# Altium schematic sheet lv_com_rs232_rs485.SchDoc(lv_com_rs232_rs485)
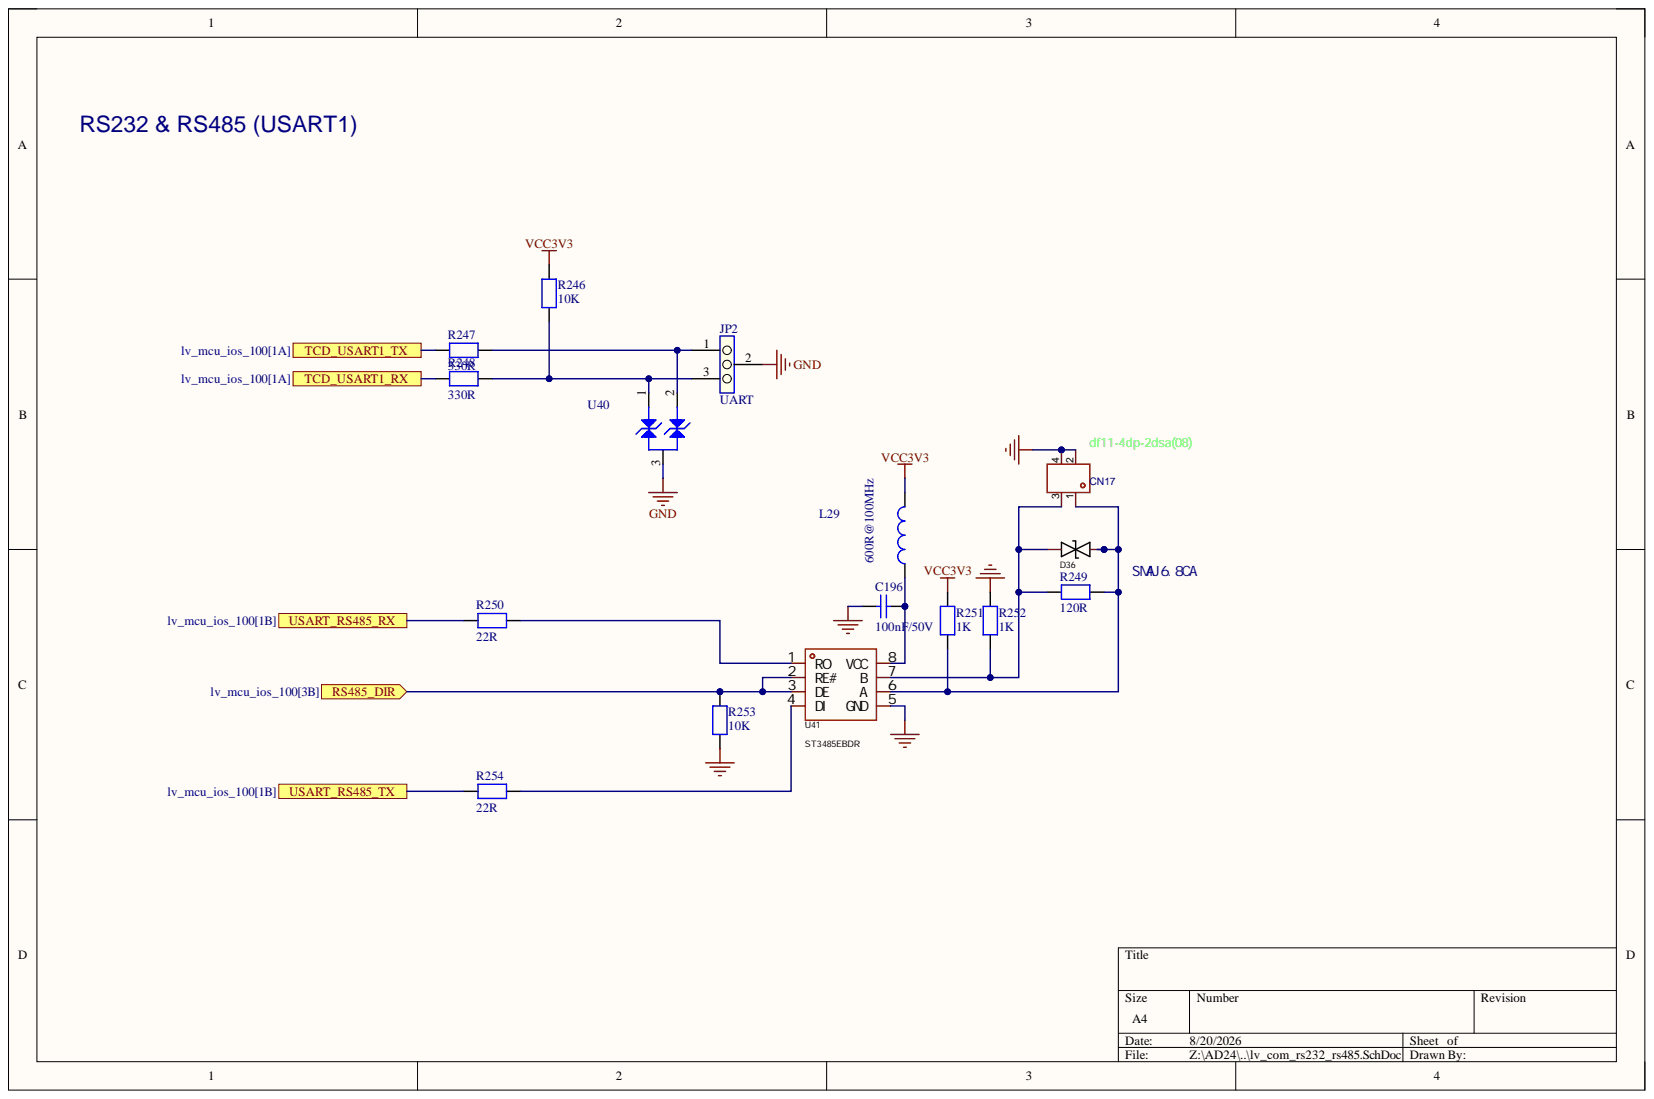
[diagram p0: lv_com_rs232_rs485.SchDoc]

## Parts
C196  100nF/50V  [C0402]
CN17  df11-4dp-2dsa(08)  [CONN-TH_DF11-4DP-2DSA-08]
D36  SMAJ6.8CA  [SMA_L4.4-W2.6-LS5.0-BI]
JP2  UART  [CON_SAMTEC_TSW-103-07-F-S]
L29  600R@100MHz  [L0603]
R246  10K  [R0402]
R247  330R  [R0402]
R248  330R  [R0402]
R249  120R  [0805]
R250  22R  [R0402]
R251  1K  [R0603]
R252  1K  [R0603]
R253  10K  [R0402]
R254  22R  [R0402]
U40  ESDCAN03-2BWY  [DIO_SOT323]  (value not drawn)
U41  ST3485EBDR  [SO-8_L4.9-W3.9-P1.27-LS5.9-BL]

## Ports
RS485_DIR, TCD_USART1_RX, TCD_USART1_TX, USART_RS485_RX, USART_RS485_TX

## Nets
GND: C196.1 CN17.2 CN17.4 JP2.2 R252.2 R253.1 U40.3 U41.5(GND)
VCC3V3: L29.2 R246.2 R251.2
RS485_DIR: R253.2 U41.2(RE#) U41.3(DE) ; port RS485_DIR
TCD_USART1_RX: R248.1 ; port TCD_USART1_RX
TCD_USART1_TX: R247.1 ; port TCD_USART1_TX
USART_RS485_RX: R250.1 ; port USART_RS485_RX
USART_RS485_TX: R254.1 ; port USART_RS485_TX
NetC196_2: C196.2 L29.1 U41.8(VCC)
NetCN17_1: CN17.1 D36.2 R249.1 R251.1 U41.6(A)
NetCN17_3: CN17.3 D36.1 R249.2 R252.1 U41.7(B)
NetJP2_1: JP2.1 R247.2 U40.2
NetJP2_3: JP2.3 R246.1 R248.2 U40.1
NetR250_2: R250.2 U41.1(RO)
NetR254_2: R254.2 U41.4(DI)

## Library data of the parts
C196 = Capacitor: 50V, 10%, X7R; Manufacturer_Part_Number: GRM155R61E105KA12D; Value: 100nF; Component Kind: Standard; ComponentLink1Description: Datasheet; ComponentLink1URL: http://tunmcdsvn.tun.st.com/Component_Datasheet_Library/Trunk/Capacitors/Yageo_Capacitor_NP0_16V-to-50V; Library Name: HiPin_Library_AD.IntLib; Package: C0402
CN17 = df11-4dp-2dsa: 插针结构:2x2P;间距:2mm;安装方式:直插;参考系列:-;总PIN数:4P;排数:2;每排PIN数:2;行距:2mm;额定电流:-;工作温度范围:-;; Manufacturer: HRS(广濑); AddInBom: yes; ModelId: 0e73d8cf2edd4592bd87ebe7b4725fde; ModelTransform: 236.22,196.85,0,0,0,0,0,0,-118.11; Number of PINs Per Row: 2; Pins Structure: 2x2P; Pitch: 2mm; Component Kind: Standard; ConvertToPcb: yes; Current Rating (Max): -; Datasheet: https://atta.szlcsc.com/upload/public/pdf/source/20200402/C506665_F433E61D9F10FCB2CA56C5EB49D0F0CF.pdf; FootprintName: CONN-TH_DF11-4DP-2DSA-08; JLCPCB Part Class: Extended Part; LCSC Part Name: 2x2P 间距:2mm 直插; Library Name: HiPin_Library_AD.IntLib; ManufacturerPart: DF11-4DP-2DSA(08); ModelName: CONN-TH_DF11-4DP-2DSA-08; Mounting Style: 直插; Number of Pins: 4P; Number of Rows: 2; Operating Temperature Range: -; Reference Series: -; Row Spacing: 2mm; Supplier: LCSC; Supplier Footprint: 插件,P=2mm; SupplierPart: C506665; Symbol: DF11-4DP-2DSA(08)
D36 = SMAJ6.8CA_C406209: 双向TVS，6.8V; Manufacturer: BORN(�����뵼��); Add into BOM: yes; Reverse Stand-Off Voltage (Vrwm): 6.8V; Type: TVS; Component Kind: Standard; Convert to PCB: yes; Datasheet: https://atta.szlcsc.com/upload/public/pdf/source/20190726/C406209_A574BC8626299E1E05AC1B67D2991239.pdf; Device: SMAJ6.8CA_C406209; JLCPCB Part Class: Extended Part; LCSC Part Name: ˫��TVS 6.8V��ֹ; Library Name: HiPin_Library_AD.IntLib; Manufacturer Part: SMAJ6.8CA; Supplier: LCSC; Supplier Footprint: SMA(DO-214AC); Supplier Part: C406209; Symbol: SMAJ6.8CA
JP2 = MCD-00111: Header, 3x1, 2,54mm, VR, TH, Height 5.84mm/2.54mm; Manufacturer: SAMTEC; Manufacturer_Part_Number: TSW-103-07-F-S; Part_Type: Connector; Initial_Board_Reference: MB1262; Item Type: Item; Path: ws/MCD_ApplicationBoards/Lists/MCDPARTSdatabase; Provided_By: SUBCO; Value: Header 3x1; Component Kind: Standard; ComponentLink1Description: Datasheet; ComponentLink1URL: http://tunmcdsvn.tun.st.com/Component_Datasheet_Library/Trunk/Connectors/Samtec_TSW-HTSW-series; Internal_Part_Number: MCD-00111; Library Name: MB1397.IntLib; Status: Released
L29 = MCD-00047: +/-25%, 350mA, 500mohm DC; Manufacturer: TAI-TECH; Manufacturer_Part_Number: FCM1608KF-601T03; Database Table Name: MCD_PARTS$; Component Kind: Standard; ComponentLink1Description: Datasheet; ComponentLink1URL: http://tunmcdsvn.tun.st.com/Component_Datasheet_Library/Trunk/Inductors/TaiTech_Bead_FCM1608_series; Library Name: MCD_PARTS_database.DbLib
R246 R253 = RES: 1%; Component Kind: Standard; Library Name: HiPin_Library_AD.IntLib; Value: 10K
R247-R252 R254 = RES: 1%; Value: 10K; Component Kind: Standard; Library Name: HiPin_Library_AD.IntLib
U40 = MCD-01228: 双线TVS,反向截止电压24V; Manufacturer: STMICROELECTRONICS; Database Table Name: MCD_PARTS$; Component Kind: Standard; Library Name: MCD_PARTS_database.DbLib; Manufacturer_Part_Number: ESDCAN03-2BWY
U41 = ST3485EBDR: RS485; Manufacturer: ST(意法半导体); Add into BOM: yes; Data Rate: 15Mbps; ESD Protect: ±12kV; Node Number: 64; Number of Driver: 1; Supply Voltage: 3V~3.6V; Types: 收发器; Component Kind: Standard; Convert to PCB: yes; Datasheet: https://atta.szlcsc.com/upload/public/pdf/source/20181012/C75809_EC9EE9FC1B4AC7950854237FAEE73CA1.pdf; Device: ST3485EBDR; JLCPCB Part Class: Extended Part; LCSC Part Name: ST3485EBDR 3.3 V供电，15 kV ESD保护，最高12 Mbps RS-485/RS-422收发器; Library Name: HiPin_Library_AD.IntLib; Manufacturer Part: ST3485EBDR; Number of receiver: 1; Operating Temperature: -40℃~+85℃; PCB3D: SO-8_L4.9-W3.9-H1.5-LS5.9-P1.27; Supplier: LCSC; Supplier Footprint: SO-8; Supplier Part: C75809; Symbol: ST3485EBDR
